# Altium schematic sheet EFR32MG24 Breakout Board MCU V1.1.SchDoc
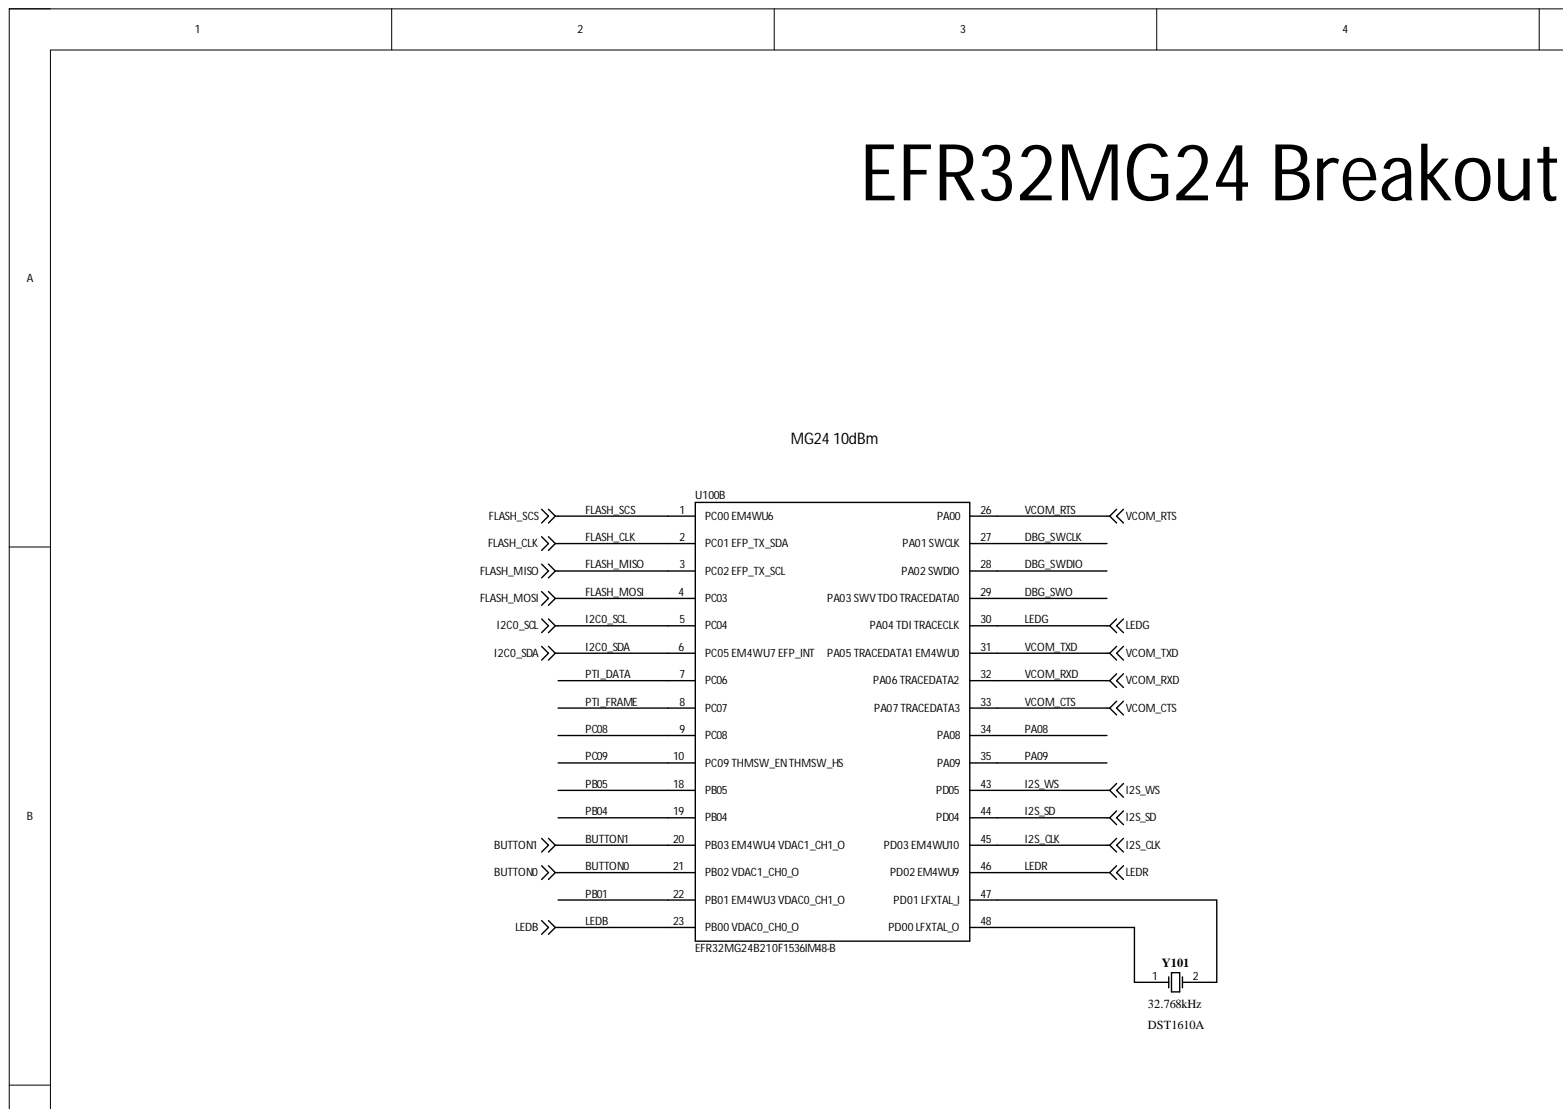
[diagram p0: EFR32MG24 Breakout Board MCU V1.1.SchDoc, top left]
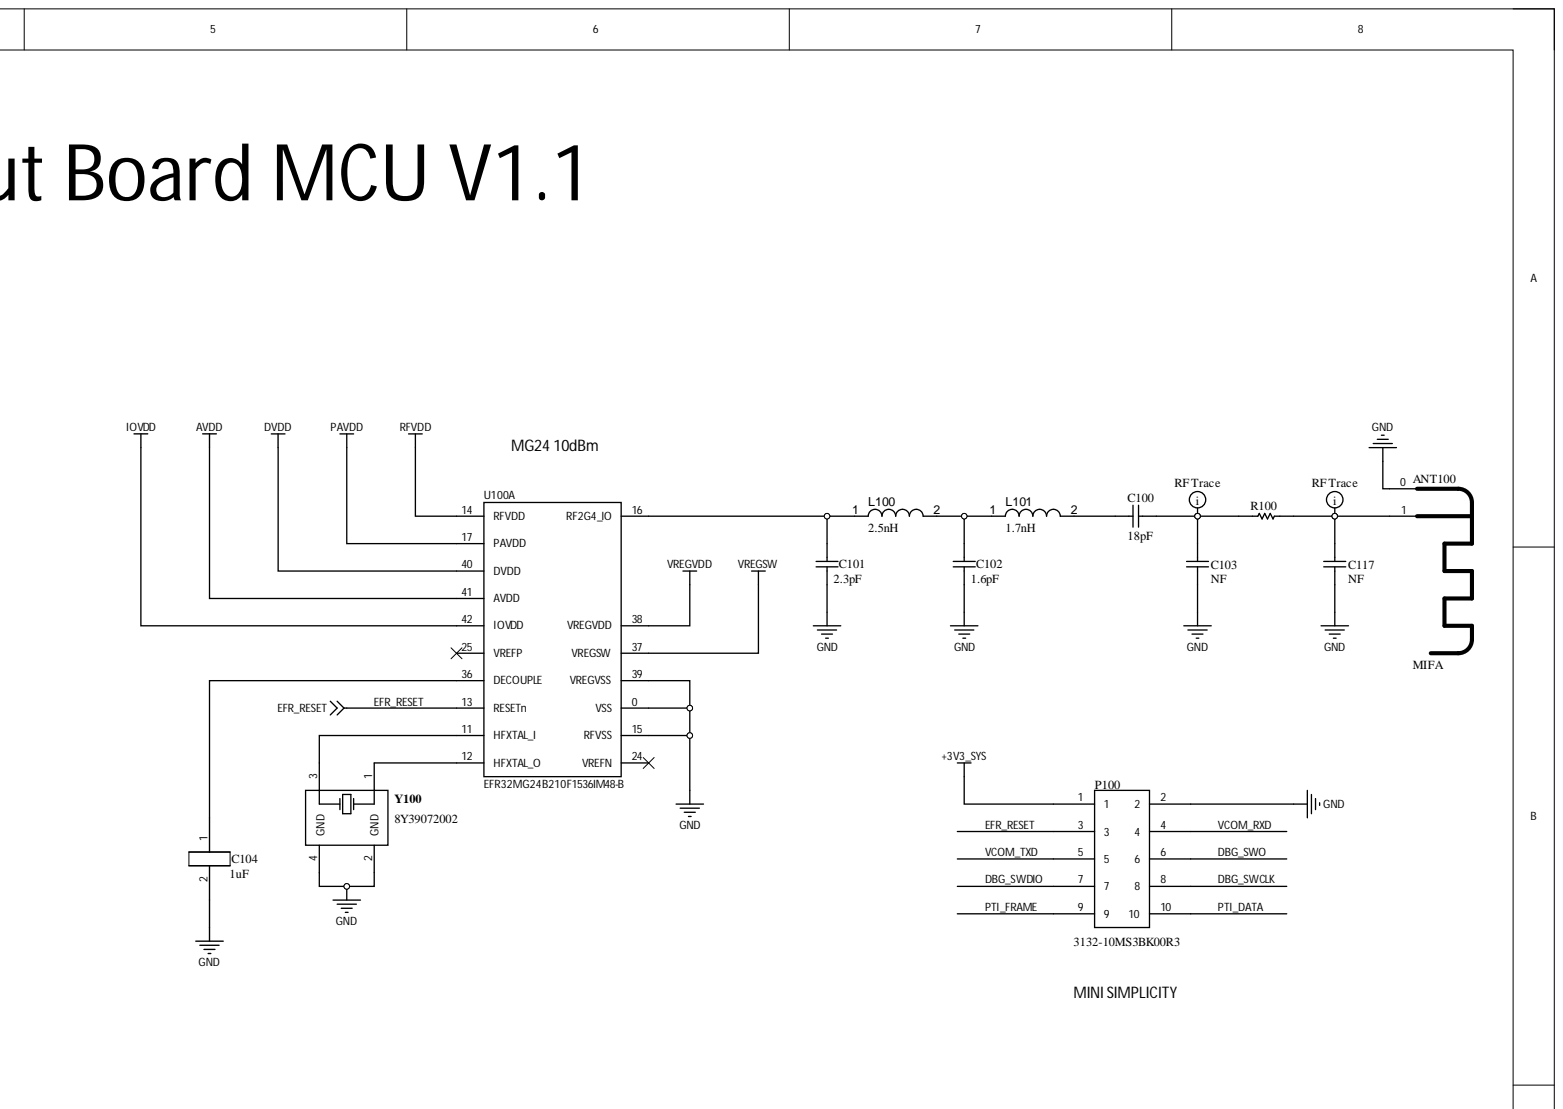
[diagram p1: EFR32MG24 Breakout Board MCU V1.1.SchDoc, top right]
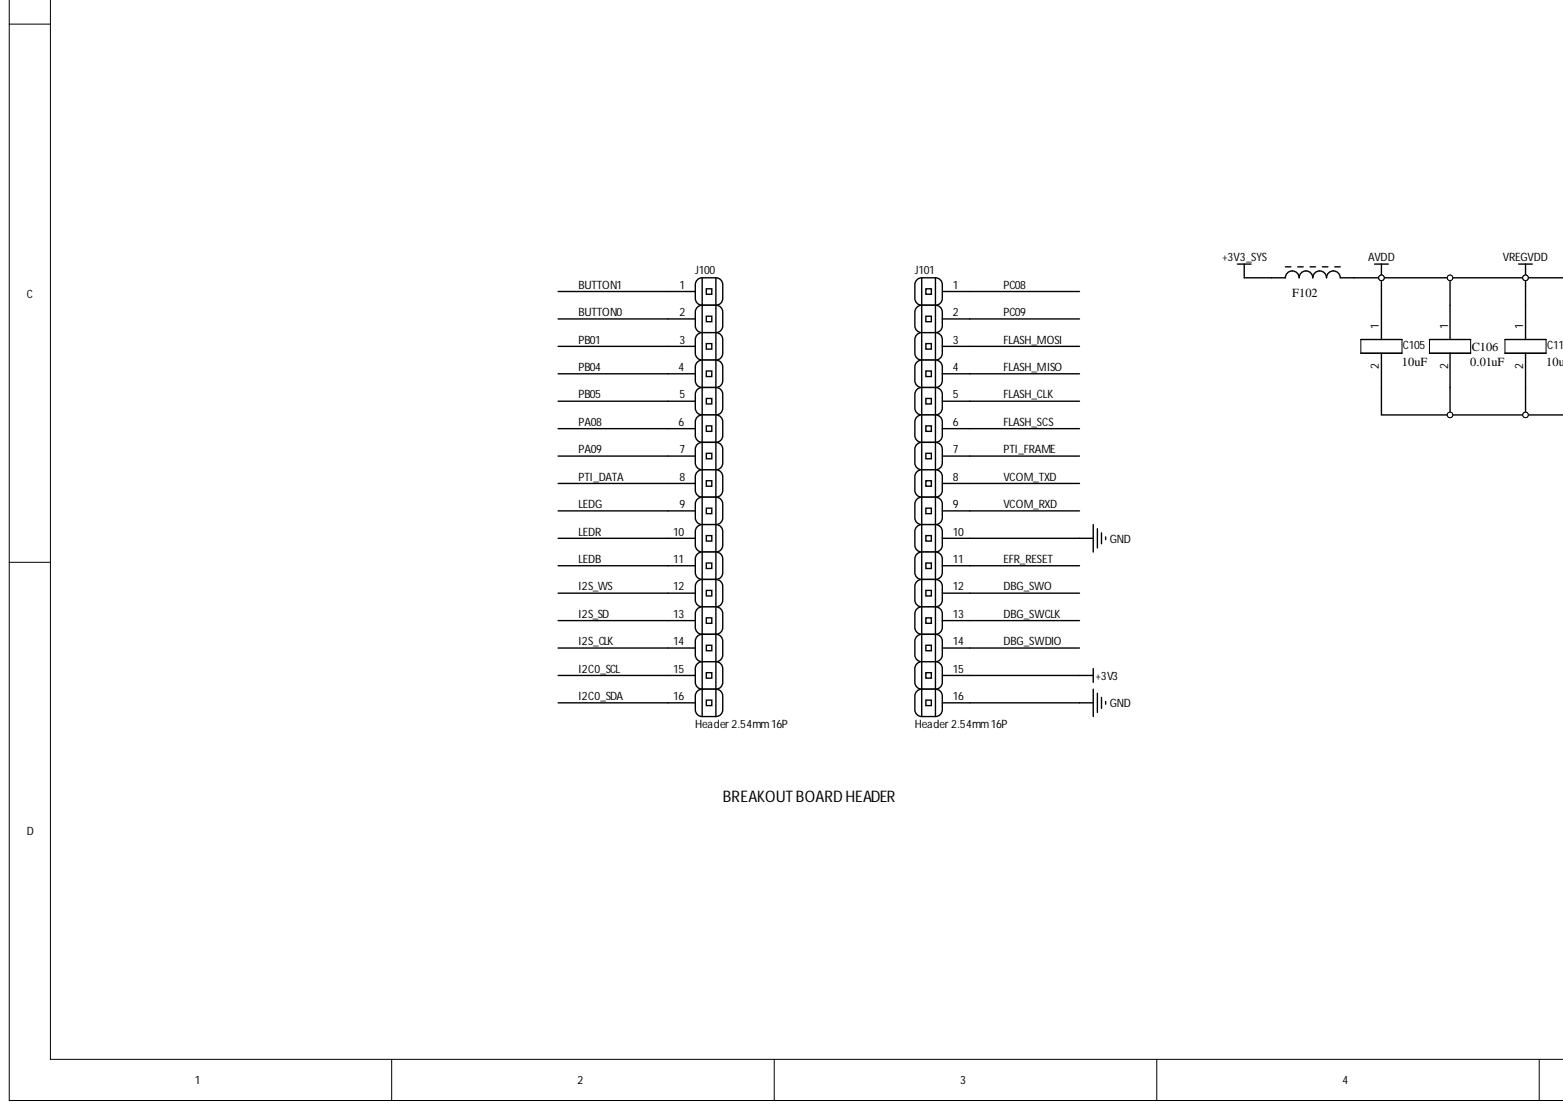
[diagram p2: EFR32MG24 Breakout Board MCU V1.1.SchDoc, bottom left]
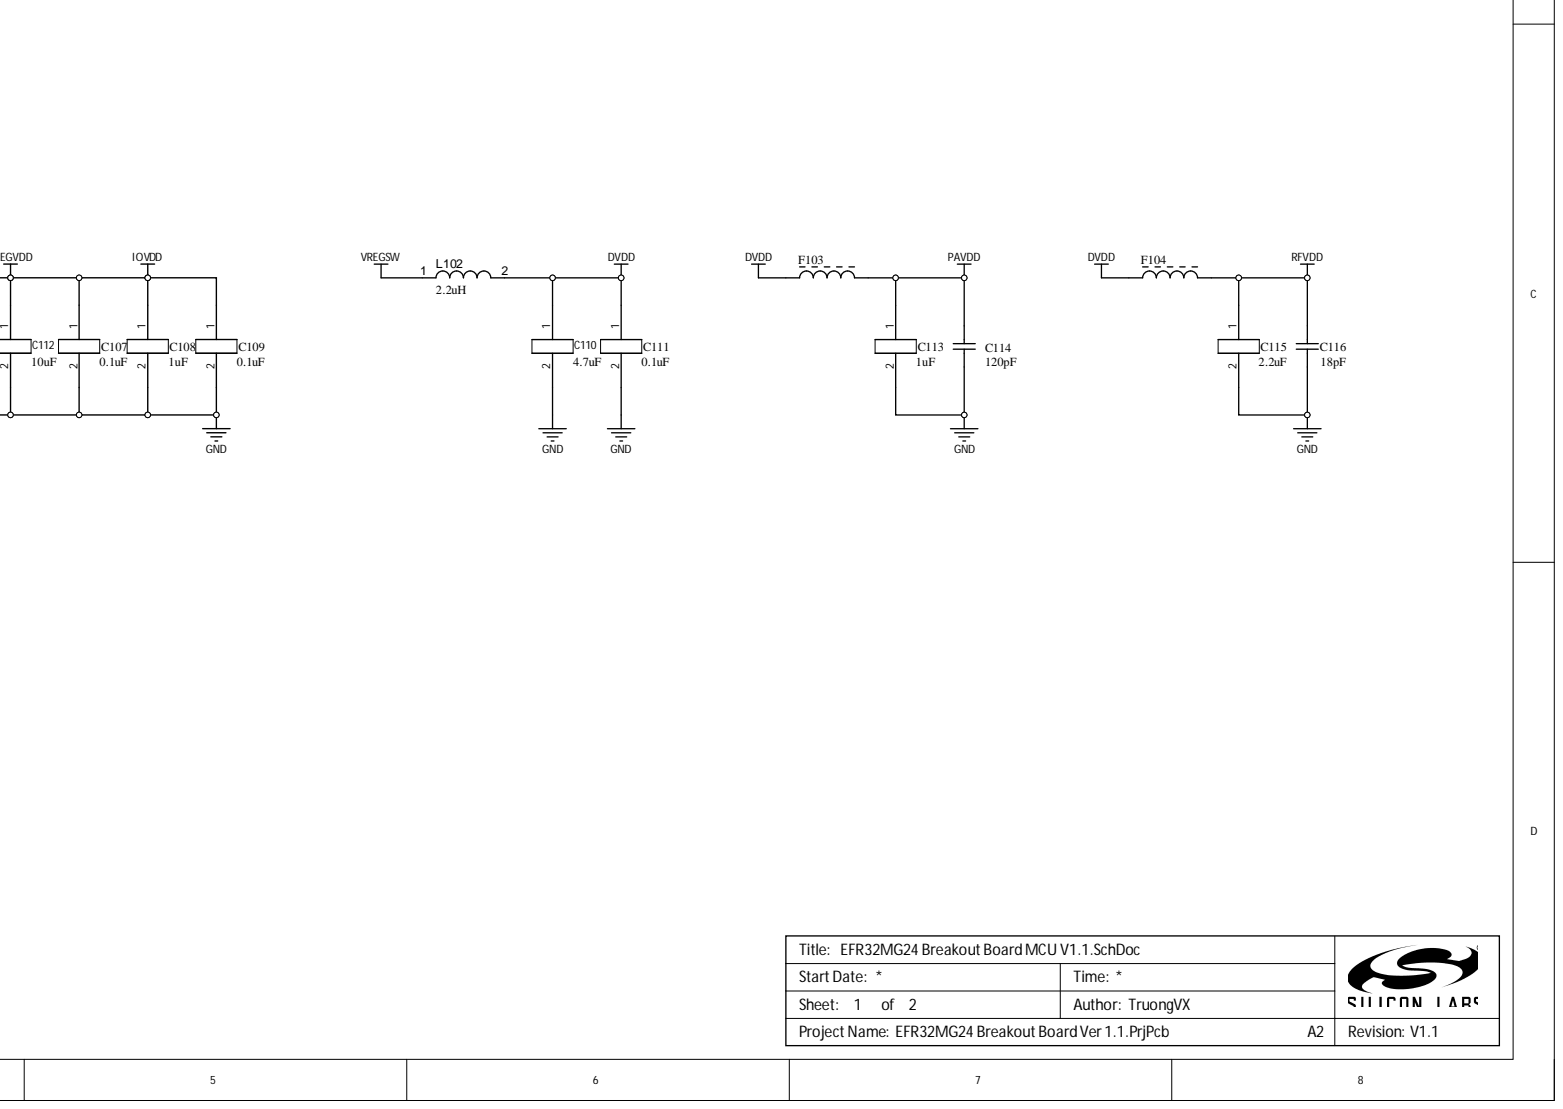
[diagram p3: EFR32MG24 Breakout Board MCU V1.1.SchDoc, bottom right]

## Parts
ANT100  MIFA  [MIFA]  (no BOM)
C100  Capacitance: 18pF  [CAPC0603X33X15LL03T05]  (hidden comment: GJM0335C1E180GB01D)
C101  Capacitance: 2.3pF  [CAPC0603X33X15LL03T05]  (hidden comment: GRM0335C1H2R3WA01D)
C102  Capacitance: 1.6pF  [CAPC0603X33X15LL03T05]  (hidden comment: GRM0335C1H1R6WA01D)
C103  Capacitance: NF  [CAPC0603X33X15LL03T05]  (hidden comment: UMK063CG1R9CTHF)
C104  1uF  [CAPC1005X05N0402]
C105  10uF  [CAPC1608X07N0603]
C106  0.01uF  [CAPC1005X05N0402]
C107  0.1uF  [CAPC1005X05N0402]
C108  1uF  [CAPC1005X05N0402]
C109  0.1uF  [CAPC1005X05N0402]
C110  4.7uF  [CAPC1608X07N0603]
C111  0.1uF  [CAPC1005X05N0402]
C112  10uF  [CAPC1608X07N0603]
C113  1uF  [CAPC1005X05N0402]
C114  Capacitance: 120pF  [CAPC0603X33X15LL03T05]  (hidden comment: GRM0335C1H121JA01D)
C115  2.2uF  [CAPC1005X05N0402]
C116  Capacitance: 18pF  [CAPC0603X33X15LL03T05]  (hidden comment: GRM0335C1H180JA01D)
C117  Capacitance: NF  [CAPC0603X33X15LL03T05]  (hidden comment: UMK063CG1R9CTHF)
F102  742692004  [WE-TMSB 0201]  (value not drawn)
F103  BLM03AG700SN1D  [CAPC0603X33X15LL03T05]  (value not drawn)
F104  BLM03AG700SN1D  [CAPC0603X33X15LL03T05]  (value not drawn)
J100  Header 2.54mm 16P  [Header 16p 2.54mm]
J101  Header 2.54mm 16P  [Header 16p 2.54mm]
L100  Inductance: 2.5nH  [CAPC0603X33X15LL03T05]  (hidden comment: LQP03HQ2N5W02)
L101  Inductance: 1.7nH  [CAPC0603X33X15LL03T05]  (hidden comment: LQP03HQ1N7W02)
L102  Inductance: 2.2uH  [INDC1608X95N]  (hidden comment: LQM18PZ2R2MFHD)
P100  3132-10MS3BK00R3  [3132-10MS3BK00R3-Footprint-3]
R100  RC0201JR-070RL  [R0201_YAGEO_L]  (value not drawn)
U100  EFR32MG24B210F1536IM48-B  [EFR32MG24_QFN48_STD]  (parts A,B)
Y100  8Y39072002  [DFN125P205X70-4N]
Y101  DST1610A  Frequency: 32.768kHz  [1TJH070DN1A0153-Footprint-1]

## Nets
+3V3: J101.15
+3V3_SYS: F102.1 P100.1
AVDD: C105.1 C106.1 C107.1 C108.1 C109.1 C112.1 F102.2 U100.38(VREGVDD) U100.41(AVDD) U100.42(IOVDD)
DVDD: C110.1 C111.1 F103.1 F104.1 L102.2 U100.40(DVDD)
GND: ANT100.0 C101.1 C102.1 C103.1 C104.2 C105.2 C106.2 C107.2 C108.2 C109.2 C110.2 C111.2 C112.2 C113.2 C114.1 C115.2 C116.1 C117.1 J101.10 J101.16 P100.2 U100.0(VSS) U100.15(RFVSS) U100.39(VREGVSS) Y100.2(GND) Y100.4(GND)
PAVDD: C113.1 C114.2 F103.2 U100.17(PAVDD)
RFVDD: C115.1 C116.2 F104.2 U100.14(RFVDD)
VREGSW: L102.1 U100.37(VREGSW)
BUTTON0: J100.2 U100.21(PB02_VDAC1_CH0_O)
BUTTON1: J100.1 U100.20(PB03_EM4WU4_VDAC1_CH1_O)
DBG_SWCLK: J101.13 P100.8 U100.27(PA01_SWCLK)
DBG_SWDIO: J101.14 P100.7 U100.28(PA02_SWDIO)
DBG_SWO: J101.12 P100.6 U100.29(PA03_SWV_TDO_TRACEDATA0)
EFR_RESET: J101.11 P100.3 U100.13(RESETn)
FLASH_CLK: J101.5 U100.2(PC01_EFP_TX_SDA)
FLASH_MISO: J101.4 U100.3(PC02_EFP_TX_SCL)
FLASH_MOSI: J101.3 U100.4(PC03)
FLASH_SCS: J101.6 U100.1(PC00_EM4WU6)
I2C0_SCL: J100.15 U100.5(PC04)
I2C0_SDA: J100.16 U100.6(PC05_EM4WU7_EFP_INT)
I2S_CLK: J100.14 U100.45(PD03_EM4WU10)
I2S_SD: J100.13 U100.44(PD04)
I2S_WS: J100.12 U100.43(PD05)
LEDB: J100.11 U100.23(PB00_VDAC0_CH0_O)
LEDG: J100.9 U100.30(PA04_TDI_TRACECLK)
LEDR: J100.10 U100.46(PD02_EM4WU9)
PA08: J100.6 U100.34(PA08)
PA09: J100.7 U100.35(PA09)
PB01: J100.3 U100.22(PB01_EM4WU3_VDAC0_CH1_O)
PB04: J100.4 U100.19(PB04)
PB05: J100.5 U100.18(PB05)
PC08: J101.1 U100.9(PC08)
PC09: J101.2 U100.10(PC09_THMSW_EN_THMSW_HS)
PTI_DATA: J100.8 P100.10 U100.7(PC06)
PTI_FRAME: J101.7 P100.9 U100.8(PC07)
VCOM_CTS: U100.33(PA07_TRACEDATA3)
VCOM_RTS: U100.26(PA00)
VCOM_RXD: J101.9 P100.4 U100.32(PA06_TRACEDATA2)
VCOM_TXD: J101.8 P100.5 U100.31(PA05_TRACEDATA1_EM4WU0)
NetANT100_1: ANT100.1 C117.2 R100.2
NetC100_1: C100.1 C103.2 R100.1
NetC100_2: C100.2 L101.2
NetC101_2: C101.2 L100.1 U100.16(RF2G4_IO)
NetC102_2: C102.2 L100.2 L101.1
NetC104_1: C104.1 U100.36(DECOUPLE)
NetU100_11: U100.11(HFXTAL_I) Y100.3
NetU100_12: U100.12(HFXTAL_O) Y100.1
NetU100_47: U100.47(PD01_LFXTAL_I) Y101.2
NetU100_48: U100.48(PD00_LFXTAL_O) Y101.1

Not connected: U100 24(VREFN) 25(VREFP)

## Library data of the parts
ANT100 = MIFA: meandered inverted-F antenna; Library Name: MG21 Breakout Board.IntLib
C100 = CMP-001-00124-5: Multilayer Ceramic Capacitors MLCC - SMD/SMT; Dielectric: C0G; Tolerance: 2%; Voltage Rating (DC): 25V; Case Code (Imperial): 0201; Case Code (Metric): 0603; Case/Package: 0201; Component Kind: Standard; Height: 0.3mm; Lead Free: Lead Free; Length: 0.6mm; Max Operating Temperature: 125°C; Min Operating Temperature: -55°C; Package Quantity: 15000; Packaging: Tape and Reel; Resistor Type: Ceramic; Termination: SMD/SMT; Thickness: 300um; Weight: 1.2E-05oz; Width: 0.3mm; Library Name: Silicon Laboratories
C101 = CMP-001-00249-1: Ceramic Capacitor, Multilayer, Ceramic, 50V, 2.17% +Tol, 2.17% -Tol, C0G, 30ppm/Cel TC, 2.3pF, Surface Mount, 0201; Capacitor Type: Ceramic; Component Kind: Standard; Max Operating Temperature: 125°C; Min Operating Temperature: -55°C; RoHS Compliant: Yes; Library Name: Silicon Laboratories
C102 = CMP-001-00250-1: Ceramic Capacitor, Multilayer, Ceramic, 50V, 3.13% +Tol, 3.13% -Tol, C0G, 30ppm/Cel TC, 1.6pF, Surface Mount, 0201; Capacitor Type: Ceramic; Component Kind: Standard; Max Operating Temperature: 125°C; Min Operating Temperature: -55°C; RoHS Compliant: Yes; Library Name: Silicon Laboratories
C103 C117 = CMP-001-00147-2: Multilayer Ceramic Capacitor 1.9pF 50V 0.25pF 0201 Paper T/R; Case Code (Imperial): 0201; Component Kind: Standard; Max Operating Temperature: 125°C; Min Operating Temperature: -55°C; Tolerance: 0.25pF; Voltage Rating: 50V; Library Name: Silicon Laboratories
C104 C106-C109 C111 C113 C115 = CAP0402: Capacitor 0402; Component Kind: Standard; Library Name: EP4185.IntLib; Package: 0402
  C104 C108 C113: Value: 1uF
  C106: Value: 0.01uF
  C107 C109 C111: Value: 0.1uF
  C115: Value: 2.2uF
C105 C110 C112 = CAP0603: Capacitor 0603; Component Kind: Standard; Library Name: EP4185.IntLib; Package: 0603
  C105 C112: Value: 10uF
  C110: Value: 4.7uF
C114 = CMP-001-00121-5: MURATA - GRM0335C1H121JA01D - Keramikvielschichtkondensator, SMD, 120 pF, 50 V, 0201 [Metrisch 0603], ± 5%, C0G / NP0, GRM Series; Voltage Rating: 50V; Case Code (Imperial): 0201; Case Code (Metric): 0603; Case/Package: 0201; Component Kind: Standard; Dielectric: C0G; Height: 0.3mm; Lead Free: Lead Free; Length: 0.024inch; Max Operating Temperature: 125°C; Min Operating Temperature: -55°C; Mount: Surface Mount; Package Quantity: 15000; Packaging: Tape and Reel; Termination: SMD/SMT; Thickness: 0.013inch; Tolerance: 5%; Voltage Rating (DC): 50V; Weight: 1.2E-05oz; Width: 0.3mm; Library Name: Silicon Laboratories
C116 = CMP-001-00217-1: Ceramic Capacitor, Multilayer, Ceramic, 50V, 5% +Tol, 5% -Tol, C0G, 30ppm/Cel TC, 18pF, Surface Mount, 0201; Capacitor Type: Ceramic; Component Kind: Standard; Max Operating Temperature: 125°C; Min Operating Temperature: -55°C; RoHS Compliant: Yes; Library Name: Silicon Laboratories
F102 = CMP-006-00035-2: WURTH ELEKTRONIK   742692004   SUPPRESSION BEAD, 0.75UH, 0.2A, 0201; Core Material: Ferrite; Current Rating: 200mA; DC Resistance (DCR): 750mR; Impedance: 240R; Self Resonant Frequency: 100MHz; Case Code (Imperial): 0201; Case Code (Metric): 0603; Case/Package: 0201; Component Kind: Standard; Depth: 0.3mm; Height: 0.3mm; Lead Free: Lead Free; Length: 0.6mm; Max DC Current: 200mA; Max Operating Temperature: 125°C; Min Operating Temperature: -55°C; Mount: Surface Mount; Package Quantity: 1; Packaging: Tape and Reel; REACH SVHC: Unknown; Termination: SMD/SMT; Test Frequency: 100MHz; Width: 0.3mm; Library Name: Silicon Laboratories
F103 F104 = CMP-006-00053-3: Ferrite Chip, 1 Function(s), 0.2A, 2 Pin(s); Current Rating: 200mA; DC Resistance-Max: 400mR; Output Impedance: 70R; Case Code: 0201; Component Kind: Standard; Height: 0.3mm; Length: 0.6mm; Material: Ferrite; Max Operating Temperature: 125°C; Min Operating Temperature: -55°C; RoHS Compliant: Yes; Width: 0.3mm; Library Name: Silicon Laboratories
J100 J101 = Header 2.54mm 16P: Vertical Header 2.54mm 16P; Maker: Leo; System: Connector; Component Kind: Standard; Library Name: EP4185.IntLib
L100 = CMP-006-00087-1: Inductor Higher Q, 2.5nH +/-0.05nH, 0603(0201); Component Kind: Standard; Library Name: Silicon Laboratories
L101 = CMP-006-00086-1: Inductor Higher Q, 1.7nH +/-0.05nH, 0603(0201); Component Kind: Standard; Library Name: Silicon Laboratories
L102 = CMP-006-00034-1: Inductor Power Chip Shielded Multi-Layer 2.2uH 20% 1MHz Ferrite 0.7A 0.47Ohm DCR 0603 Automotive T/R; Core Material: Ferrite,Metal; Current Rating: 700mA; DC Resistance (DCR): 470mR; Saturation Current: 300mA; Self Resonant Frequency: 80MHz; Case Code (Imperial): 1008; Case Code (Metric): 2520; Case/Package: 0603; Component Kind: Standard; Max Operating Temperature: 125°C; Min Operating Temperature: -55°C; Mount: Surface Mount; Packaging: Cut Tape; Ratings: AEC-Q200; Resistor Type: Thin Film; Shielding: Shielded; Test Frequency: 1MHz; Tolerance: 20%; Library Name: Silicon Laboratories
P100 = CMP-002-00076-7: MINI-SIMPLICITY; Manufacture: WCON; Connector Type: Male Pin; Number of Positions: 10; Pitch: 0.05inch; Component Kind: Standard; Mount: Surface Mount; MPN: 3132-10MS3BK00R3; Number of Pins: 10; Number of Rows: 2; Row Spacing: 0.05inch; Library Name: Silicon Laboratories
R100 = CMP-009-00198-5: Res Jumper Thick Film 0201 0 Ohm 5% 1/20W Molded SMD Paper T/R; Max Power Dissipation: 50mW; Resistance: 0R; Voltage Rating: 25V; Case Code (Imperial): 0201; Case Code (Metric): 0603; Case/Package: 0201; Component Kind: Standard; Construction: Rectangular; Contact Plating: Tin,Matte; Depth: 0.3mm; Features: Moisture Resistant; Height: 0.01inch; Lead Free: Lead Free; Length: 0.6mm; Max Operating Temperature: 125°C; Military Standard: Not; Min Operating Temperature: -55°C; Number of Terminations: 2; Package Quantity: 10000; Packaging: Tape and Reel; Power Rating: 50mW; Radiation Hardening: No; REACH SVHC: Unknown; Resistance Tolerance: 5%; Resistor Type: Thick Film; Series: RC; Size Code: 0201; Termination: SMD/SMT; Tolerance: 5%; Voltage Rating (DC): 25V; Weight: 6E-06oz; Width: 0.012inch; Library Name: Silicon Laboratories
U100 = EFR32MG24B210F1536IM48-B: MG24, 2.4 GHz, 1536kB Flash, 256kB RAM, +10 dBm, Secure Vault High, MVP +125C, QFN48; Manufacturer: Silicon Labs; Component Kind: Standard; Library Name: EP4185.IntLib; Manufacturer Part Number: EFR32MG24B210F1536IM48-B; Pricing 1: 1=10.85000, 10=9.94600, 25=9.04200, 80=8.13775, 230=7.45965, 440=6.78150, 945=6.16499 (USD); RoHS: No; Stock 1: 290; Supplier 1: Digi-Key; Supplier Part Number 1: 336-EFR32MG24B210F1536IM48-B-ND
Y100 = CMP-003-00050-1: XTAL, 39MHz, +/-8 ppm, 10pF, 2.0 x 1.6 x 0.5 mm; Component Kind: Standard; Library Name: Silicon Laboratories
Y101 = CMP-003-00051-1: Crystal 32.768KHZ 7PF SMD,1TJH070DN1A0153,1.6x1.0x0.5mm; Component Kind: Standard; Library Name: Silicon Laboratories
